# Altium schematic sheet PSC_LED_KEYBOARD.SchDoc
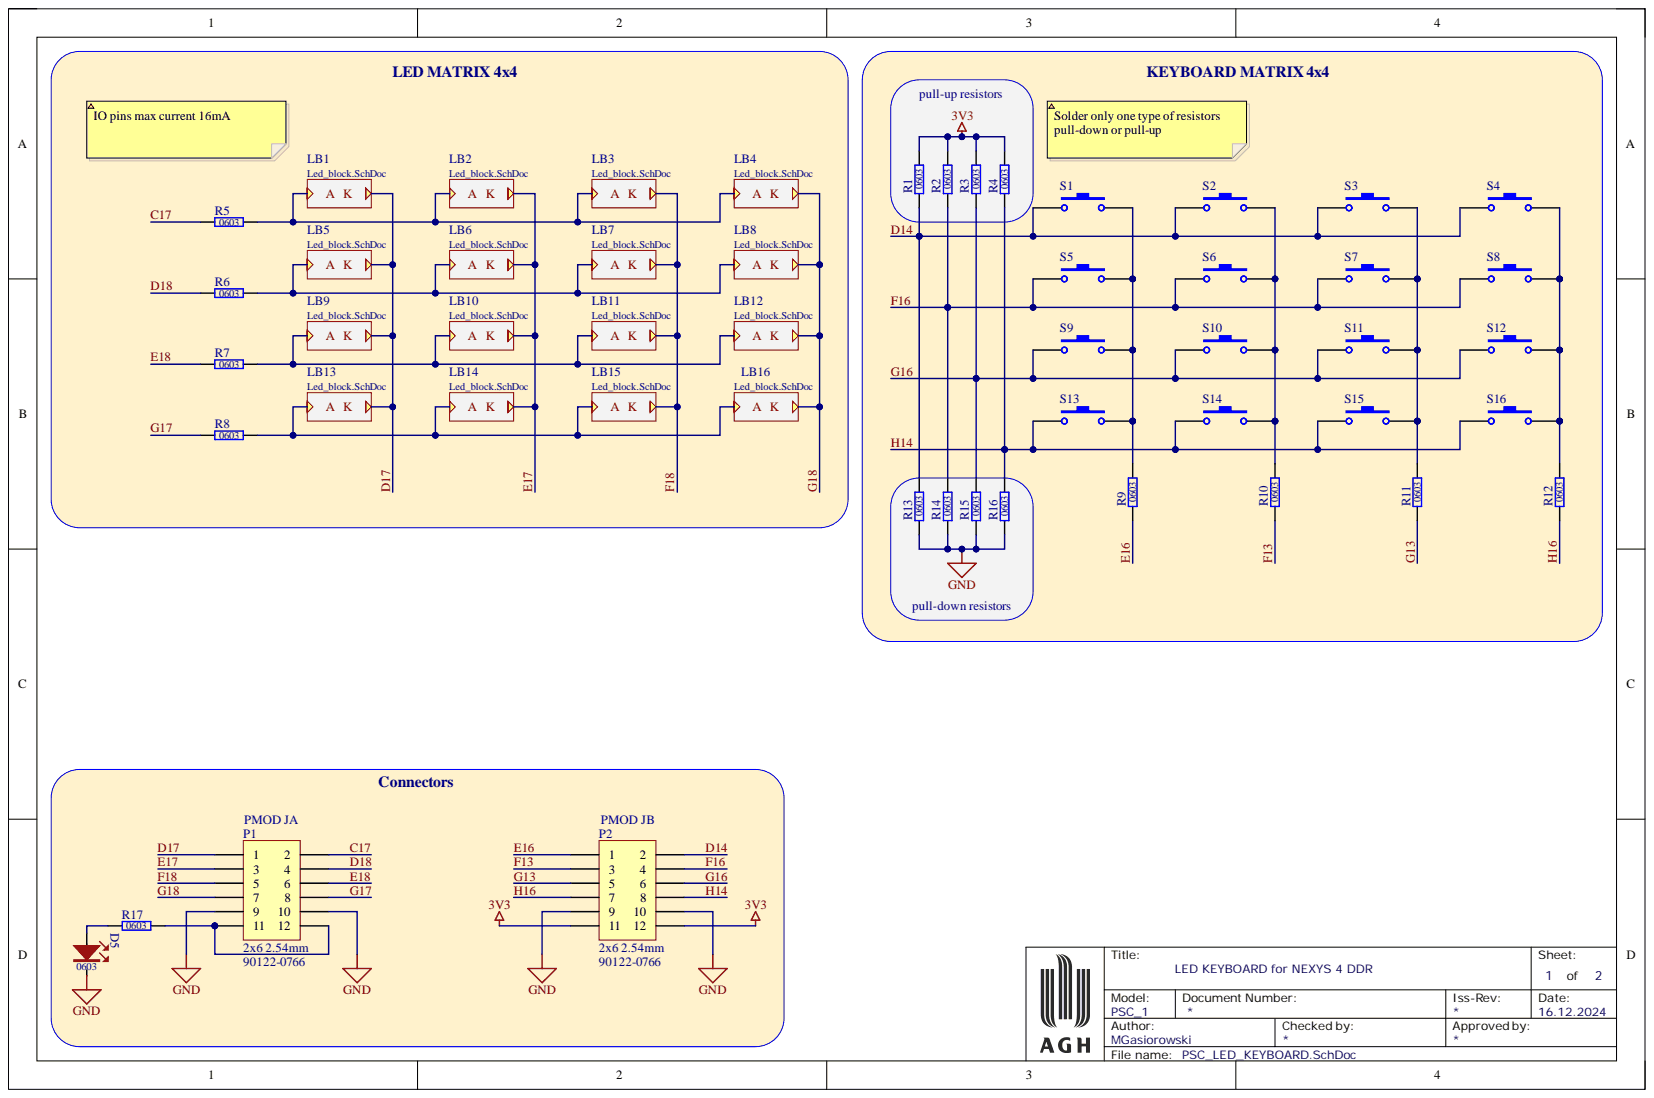
[diagram p0: PSC_LED_KEYBOARD.SchDoc]

## Parts
D5  SCH_Footprint: 0603  [OL_0603_1608]  (hidden comment: Red LED 0603)
P1  value 90122-0766  Manufacturer Part Number: 90122-0766  SCH_Footprint: 2x6 2.54mm  [P_HEADER_2x6_2.54_RIGHT_ANGLE]  (hidden comment: Goldpin male)
P2  value 90122-0766  Manufacturer Part Number: 90122-0766  SCH_Footprint: 2x6 2.54mm  [P_HEADER_2x6_2.54_RIGHT_ANGLE]  (hidden comment: Goldpin male)
R1  SCH_Footprint: 0603  [R_0603_1608]  (hidden comment: Resistor)
R2  SCH_Footprint: 0603  [R_0603_1608]  (hidden comment: Resistor)
R3  SCH_Footprint: 0603  [R_0603_1608]  (hidden comment: Resistor)
R4  SCH_Footprint: 0603  [R_0603_1608]  (hidden comment: Resistor)
R5  SCH_Footprint: 0603  [R_0603_1608]  (hidden comment: Resistor)
R6  SCH_Footprint: 0603  [R_0603_1608]  (hidden comment: Resistor)
R7  SCH_Footprint: 0603  [R_0603_1608]  (hidden comment: Resistor)
R8  SCH_Footprint: 0603  [R_0603_1608]  (hidden comment: Resistor)
R9  SCH_Footprint: 0603  [R_0603_1608]  (hidden comment: Resistor)
R10  SCH_Footprint: 0603  [R_0603_1608]  (hidden comment: Resistor)
R11  SCH_Footprint: 0603  [R_0603_1608]  (hidden comment: Resistor)
R12  SCH_Footprint: 0603  [R_0603_1608]  (hidden comment: Resistor)
R13  SCH_Footprint: 0603  [R_0603_1608]  (hidden comment: Resistor)
R14  SCH_Footprint: 0603  [R_0603_1608]  (hidden comment: Resistor)
R15  SCH_Footprint: 0603  [R_0603_1608]  (hidden comment: Resistor)
R16  SCH_Footprint: 0603  [R_0603_1608]  (hidden comment: Resistor)
R17  SCH_Footprint: 0603  [R_0603_1608]  (hidden comment: Resistor)
S1  S_TACTSWITCH  [S_TactSwitch_12x12]  (value not drawn)
S2  S_TACTSWITCH  [S_TactSwitch_12x12]  (value not drawn)
S3  S_TACTSWITCH  [S_TactSwitch_12x12]  (value not drawn)
S4  S_TACTSWITCH  [S_TactSwitch_12x12]  (value not drawn)
S5  S_TACTSWITCH  [S_TactSwitch_12x12]  (value not drawn)
S6  S_TACTSWITCH  [S_TactSwitch_12x12]  (value not drawn)
S7  S_TACTSWITCH  [S_TactSwitch_12x12]  (value not drawn)
S8  S_TACTSWITCH  [S_TactSwitch_12x12]  (value not drawn)
S9  S_TACTSWITCH  [S_TactSwitch_12x12]  (value not drawn)
S10  S_TACTSWITCH  [S_TactSwitch_12x12]  (value not drawn)
S11  S_TACTSWITCH  [S_TactSwitch_12x12]  (value not drawn)
S12  S_TACTSWITCH  [S_TactSwitch_12x12]  (value not drawn)
S13  S_TACTSWITCH  [S_TactSwitch_12x12]  (value not drawn)
S14  S_TACTSWITCH  [S_TactSwitch_12x12]  (value not drawn)
S15  S_TACTSWITCH  [S_TactSwitch_12x12]  (value not drawn)
S16  S_TACTSWITCH  [S_TactSwitch_12x12]  (value not drawn)

## Nets
3V3: P2.11 P2.12 R1.2 R2.2 R3.2 R4.2
GND: D5.1 P1.9 P1.10 P2.9 P2.10 R13.1 R14.1 R15.1 R16.1
C17: P1.2 R5.1
D14: P2.2 R1.1 R13.2 S1.1 S2.1 S3.1 S4.1
D17: P1.1
D18: P1.4 R6.1
E16: P2.1 R9.1
E17: P1.3
E18: P1.6 R7.1
F13: P2.3 R10.1
F16: P2.4 R2.1 R14.2 S5.1 S6.1 S7.1 S8.1
F18: P1.5
G13: P2.5 R11.1
G16: P2.6 R3.1 R15.2 S9.1 S10.1 S11.1 S12.1
G17: P1.8 R8.1
G18: P1.7
H14: P2.8 R4.1 R16.2 S13.1 S14.1 S15.1 S16.1
H16: P2.7 R12.1
NetD5_2: D5.2 R17.1
NetP1_11: P1.11 P1.12 R17.2
NetR9_2: R9.2 S1.2 S5.2 S9.2 S13.2
NetR10_2: R10.2 S2.2 S6.2 S10.2 S14.2
NetR11_2: R11.2 S3.2 S7.2 S11.2 S15.2
NetR12_2: R12.2 S4.2 S8.2 S12.2 S16.2

Not connected: R5 2; R6 2; R7 2; R8 2

## Library data of the parts
D5 = OL_R_0603_LTST-C193KRKT-5A: LED_RED; Manufacturer: Lite-On; Database Table Name: Optoelectronics; Component Kind: Standard; HelpURL: https://pl.mouser.com/datasheet/2/239/LTST-C193KRKT-5A-1141706.pdf; Library Name: Library.DBLib; Manufacturer Part Number: LTST-C193KRKT-5A; Part Number: OL_R_0603_LTST-C193KRKT-5A; Supplier 1: TME; Supplier 2: RS Components; Supplier 3: Farnell; Supplier 4: Mouser; Supplier 5: Digi-Key; Supplier 6: LCSC; Supplier Part Number 1: LTST-C193KRKT-5A; Supplier Part Number 2: 6921041; Supplier Part Number 4: 859-LTSTC193KRKT5A; Supplier Part Number 5: 160-1830-1-ND; Value: LTST-C193KRKT-5A
P1 P2 = P_HEADER_2x6_2.54_ANGLE: Pin Header; Manufacturer: Molex; Database Table Name: Connectors; Component Kind: Standard; HelpURL: https://datasheet.octopart.com/90122-0766-Molex-datasheet-101610747.pdf; Library Name: Library.DBLib; Part Number: P_HEADER_2x6_2.54_ANGLE; Supplier 1: TME; Supplier 2: RS Components; Supplier 3: Farnell; Supplier 4: Mouser; Supplier 5: Digi-Key; Supplier 6: LCSC; Supplier Part Number 2: 3606572; Supplier Part Number 3: 9733710; Supplier Part Number 4: 538-90122-0766; Supplier Part Number 5: WM50030-12-ND
R1-R17 = R_10k_0603_0.1: Manufacturer: Panasonic; Manufacturer Part Number: ERA3ARB103V; Database Table Name: Resistors; Power: 100mW; Tolerance: 0.1%; Value: 10k; Voltage: 75V; Component Kind: Standard; HelpURL: https://www.mouser.pl/datasheet/2/315/AOA0000C307-1149632.pdf; Library Name: Library.DBLib; Part Number: R_10k_0603_0.1; Supplier 1: TME; Supplier 2: RS Components; Supplier 3: Farnell; Supplier 4: Mouser; Supplier 5: Digi-Key; Supplier 6: LCSC; Supplier Part Number 1: ERA3ARB103V; Supplier Part Number 2: 1829211; Supplier Part Number 3: 1717597; Supplier Part Number 4: 667-ERA-3ARB103V; Supplier Part Number 5: P10KBDCT-ND
S1-S16 = S_TACTSWITCH: Tact switch; Component Kind: Standard; Library Name: GG_LIB.IntLib
